# Altium schematic sheet HDMI.SchDoc(HDMI)
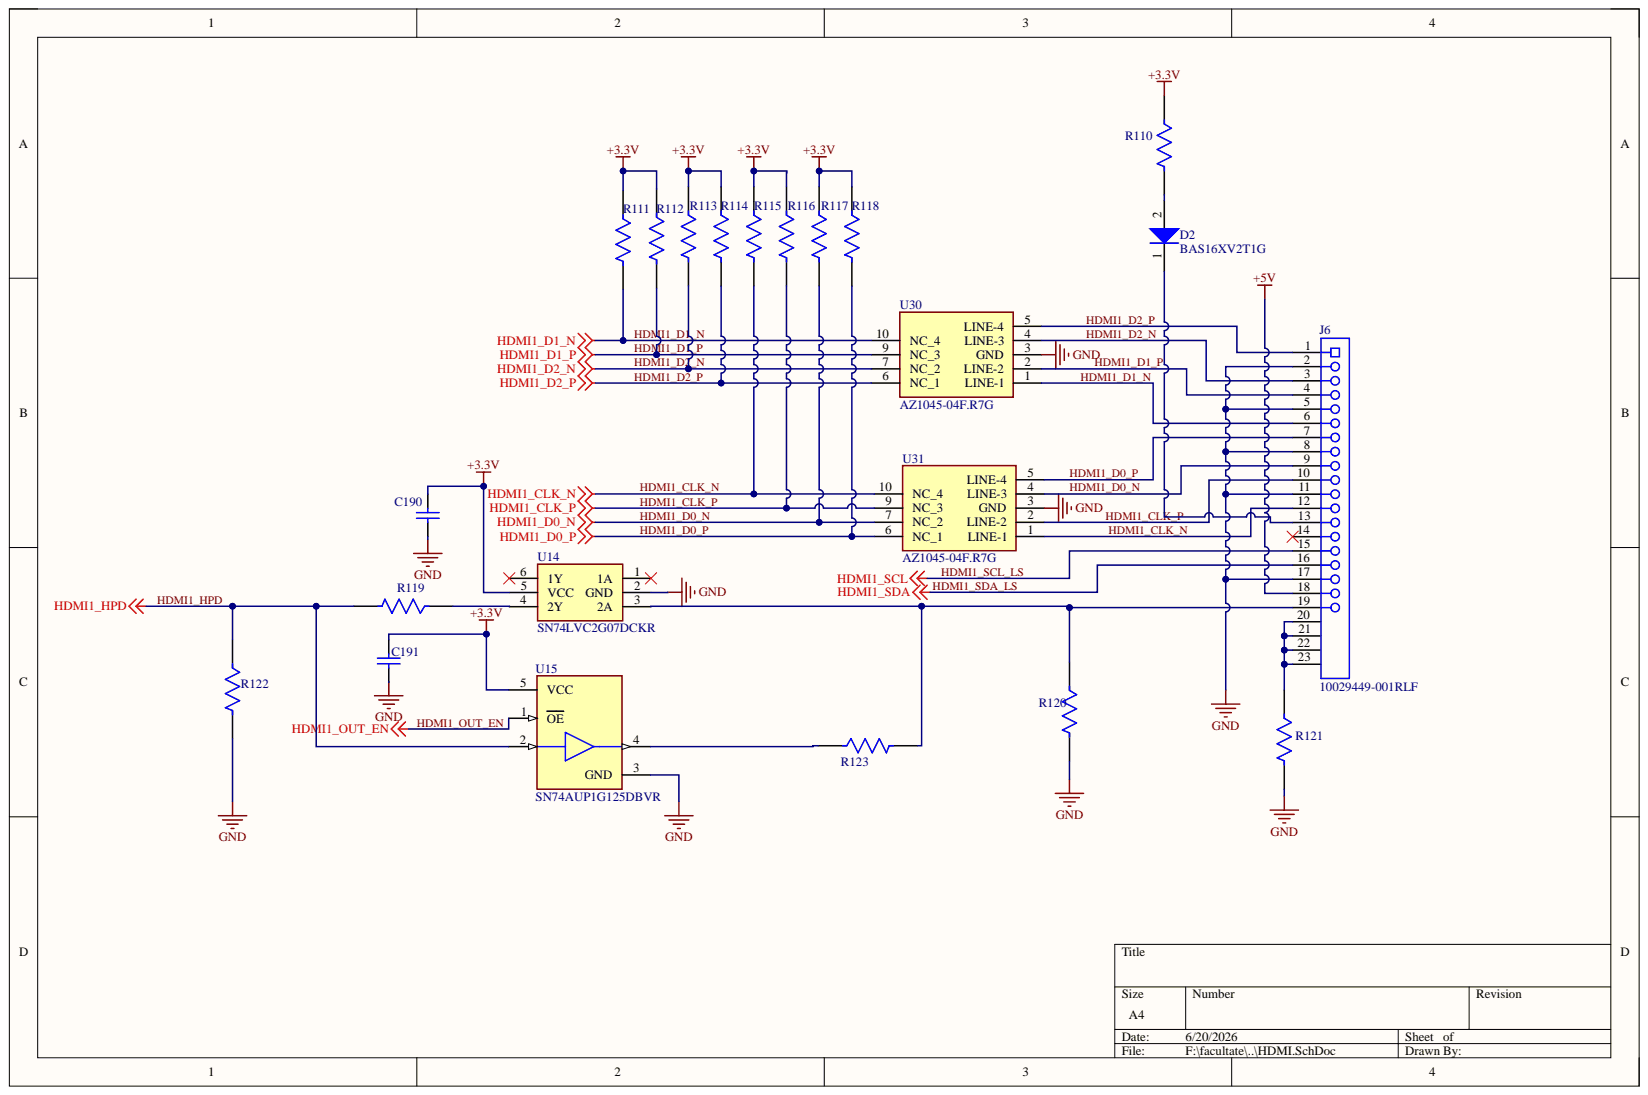
[diagram p0: HDMI.SchDoc]

## Parts
C190  VJ0805Y152KXAAC  [CAPC2013X145X48NL20T23]  (value not drawn)
C191  VJ0805Y152KXAAC  [CAPC2013X145X48NL20T23]  (value not drawn)
D2  BAS16XV2T1G  [ONSC-SOD-523-2-502-01_V]
J6  10029449-001RLF  [FCI-10029449-001RLF_V]
R110  MCU0805MD4991BP100  [FP-MCU0805-MFG]  (value not drawn)
R111  MCU0805MD4991BP100  [FP-MCU0805-MFG]  (value not drawn)
R112  MCU0805MD4991BP100  [FP-MCU0805-MFG]  (value not drawn)
R113  MCU0805MD4991BP100  [FP-MCU0805-MFG]  (value not drawn)
R114  MCU0805MD4991BP100  [FP-MCU0805-MFG]  (value not drawn)
R115  MCU0805MD4991BP100  [FP-MCU0805-MFG]  (value not drawn)
R116  MCU0805MD4991BP100  [FP-MCU0805-MFG]  (value not drawn)
R117  MCU0805MD4991BP100  [FP-MCU0805-MFG]  (value not drawn)
R118  MCU0805MD4991BP100  [FP-MCU0805-MFG]  (value not drawn)
R119  MCU0805MD4991BP100  [FP-MCU0805-MFG]  (value not drawn)
R120  MCU0805MD4991BP100  [FP-MCU0805-MFG]  (value not drawn)
R121  MCU0805MD4991BP100  [FP-MCU0805-MFG]  (value not drawn)
R122  MCU0805MD4991BP100  [FP-MCU0805-MFG]  (value not drawn)
R123  MCU0805MD4991BP100  [FP-MCU0805-MFG]  (value not drawn)
U14  SN74LVC2G07DCKR  [SOT65P210X110-6N]
U15  SN74AUP1G125DBVR  [FP-DBV0005A-IPC_C]
U30  AZ1045-04F.R7G  [AZ104504FR7G]
U31  AZ1045-04F.R7G  [AZ104504FR7G]

## Nets
+3.3V: C190.2 C191.2 R110.1 R111.1 R112.1 R113.1 R114.1 R115.1 R116.1 R117.1 R118.1 U14.5(VCC) U15.5(VCC)
+5V: J6.18
GND: C190.1 C191.1 J6.2 J6.5 J6.8 J6.11 J6.17 R120.2 R121.2 R122.2 U14.2(GND) U15.3(GND) U30.3(GND) U31.3(GND)
HDMI1_CLK_N: J6.12 R115.2 U31.1(LINE-1) U31.10(NC_4)
HDMI1_CLK_P: J6.10 R116.2 U31.2(LINE-2) U31.9(NC_3)
HDMI1_D0_N: J6.9 R117.2 U31.4(LINE-3) U31.7(NC_2)
HDMI1_D0_P: J6.7 R118.2 U31.5(LINE-4) U31.6(NC_1)
HDMI1_D1_N: J6.6 R111.2 U30.1(LINE-1) U30.10(NC_4)
HDMI1_D1_P: J6.4 R112.2 U30.2(LINE-2) U30.9(NC_3)
HDMI1_D2_N: J6.3 R113.2 U30.4(LINE-3) U30.7(NC_2)
HDMI1_D2_P: J6.1 R114.2 U30.5(LINE-4) U30.6(NC_1)
HDMI1_HPD: R119.2 R122.1 U15.2
HDMI1_OUT_EN: U15.1(OE)
HDMI1_SCL_LS: J6.15
HDMI1_SDA_LS: J6.16
NetD2_1: D2.1 J6.13
NetD2_2: D2.2 R110.2
NetJ6_19: J6.19 R120.1 R123.2 U14.3(2A)
NetJ6_20: J6.20 J6.21 J6.22 J6.23 R121.1
NetR119_1: R119.1 U14.4(2Y)
NetR123_1: R123.1 U15.4

Not connected: J6 14; U14 1(1A) 6(1Y)

## Library data of the parts
C190 C191 = CMP-2007-01729-1: Manufacturer: Vitramon; Component Kind: Standard; Library Name: Altium Content Vault; Part Number: VJ0805Y152KXAAC
D2 = CMP-1054-00076-1: Switching Diode, 2-Pin SOD-523, Pb-Free, Tape and Reel; Manufacturer: On Semiconductor; Configuration: Single; IF Max (A): 0.2; trr Max (ns): 6; Component Kind: Standard; ComponentLink1Description: Manufacturer URL; ComponentLink1URL: http://www.onsemi.com/; ComponentLink2Description: Datasheet; ComponentLink2URL: http://www.onsemi.com/pub_link/Collateral/BAS16XV2T1-D.PDF; ComponentLink3Description: Package Specification; ComponentLink3URL: http://www.onsemi.com/pub_link/Collateral/502-01.PDF; DatasheetVersion: Rev. 7; Library Name: Altium Content Vault; Mounting Technology: Surface Mount; PackageDescription: 2-Pin SOD, Body 1.2 x 0.8 mm; PackageReference: SOD-523-2-502-01; PackageVersion: Rev. E, 09/2010; Packing: Tape and Reel; PartNumber: BAS16XV2T1G; RoHS: TRUE
J6 = CMP-1698-00003-1: Surface Mount Type A HDMI Receptacle without Flange, 19 Positions, 0.5 mm Pitch, -55 to 85 degC, RoHS, Tape and Reel; Component Kind: Standard; ComponentLink1Description: Manufacturer URL; ComponentLink1URL: http://portal.fciconnect.com/; ComponentLink2Description: Datasheet; ComponentLink2URL: https://datasheet.ciiva.com/851/10029449-851120.pdf; DatasheetVersion: Rev. S, 06/2012; Library Name: Altium Content Vault; PackageDescription: 19-Pin Surface Mount Device, Body 15 x 9 mm, Pitch 0.5 mm; PackageReference: 10029449-001RLF; RoHSCompliant: false
R110-R123 = CMP-02407-000217-1: RES 1.05K OHM 0.5% 1/5W 0805; Power Rating: 200mW; Resistance: 4.99kR; Tolerance: 0.1%; Voltage Rating: 150V; Case Code (Imperial): 0805; Case Code (Metric): 2012; Case/Package: 0805; Component Kind: Standard; Composition: Thin Film; Height: 0.52mm; Length: 2mm; Library Name: Altium Content Vault; Max Operating Temperature: 155°C; Min Operating Temperature: -55°C; Package Quantity: 1000; Packaging: Tape and Reel; Termination: SMD/SMT; Weight: 0.000194oz; Width: 1.25mm
U14 = SN74LVC2G07DCKR: Integrated Circuit; Manufacturer_Name: Texas Instruments; Arrow Part Number: SN74LVC2G07DCKR; Arrow Price/Stock: https://www.arrow.com/en/products/sn74lvc2g07dckr/texas-instruments?region=nac; Component Kind: Standard; Datasheet Link: https://datasheet.lcsc.com/szlcsc/Texas-Instruments-TI-SN74LVC2G07DCKR_C7849.pdf; Height: 1.1mm; Manufacturer_Part_Number: SN74LVC2G07DCKR; Mouser Part Number: 595-SN74LVC2G07DCKR; Mouser Price/Stock: https://www.mouser.co.uk/ProductDetail/Texas-Instruments/SN74LVC2G07DCKR?qs=EIjG%252BN7kn%252Bn6uhrXwDLE9Q%3D%3D
U15 = CMP-0859-00054-4: IC BUF NON-INVERT 3.6V SOT23-5; High Level Output Current: -4mA; Logic Function: Buffer; Low Level Output Current: 4mA; Min Supply Voltage: 800mV; Number of Bits: 1; Output Type: 3-STATE; Polarity: Non-Inverting; Propagation Delay: 18.7ns; Quiescent Current: 500nA; Turn-On Delay Time: 25.5ns; Case/Package: SOT-23; Component Kind: Standard; Lead Free: Lead Free; Library Name: Altium Content Vault; Max Operating Temperature: 85°C; Max Supply Voltage: 3.6V; Min Operating Temperature: -40°C; Mount: Surface Mount; Number of Bits per Element: 1; Number of Channels: 1; Number of Elements: 1; Number of Gates: 1; Number of Input Lines: 1; Number of Inputs: 1; Number of Output Lines: 1; Number of Outputs: 1; Number of Pins: 5; Package Quantity: 3000; Packaging: Tape and Reel; Radiation Hardening: No; REACH SVHC: No SVHC; RoHS Compliant: Yes; Series: 74AUP; Technology: CMOS
U30 U31 = AZ1045-04F.R7G: Diode ESD (Uni-directional); Manufacturer_Name: Amazing Microelectronic Corp.; Component Kind: Standard; Datasheet Link: https://download.datasheets.com/pdfs/2013/10/3/2/31/47/175/amzng_/manual/az1045-04f_datasheet.pdf?_ga=2.166408059.458781722.1648096097-1927931834.1642822301; Height: 0.55mm; Manufacturer_Part_Number: AZ1045-04F.R7G
